# Altium schematic sheet UTHP BeagleBone Headers v2.SchDoc
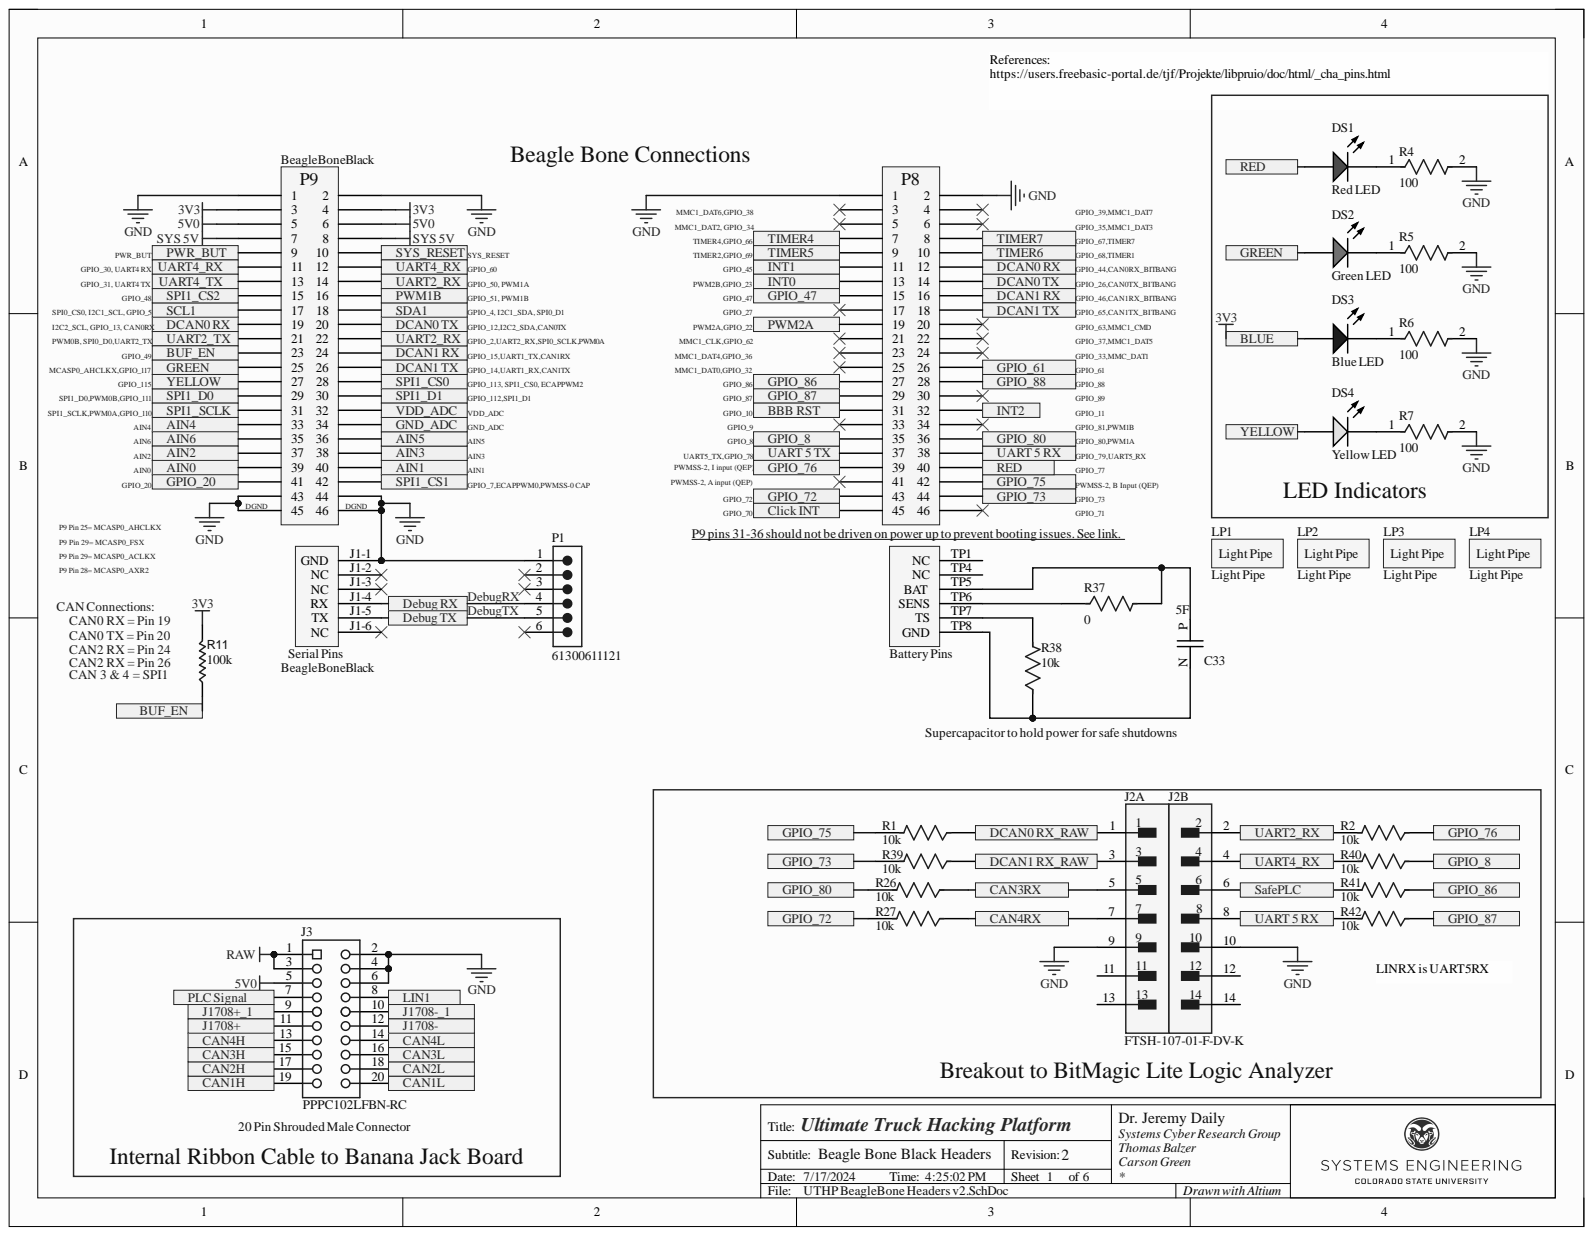
[diagram p0: UTHP BeagleBone Headers v2.SchDoc]

## Parts
BeagleBoneBlack  BeagleBoneBlack  [Beagleboneblack]
C33  5F  [New footprint 1]
DS1  Red LED  [LTST-C191KRKT_V]
DS2  Green LED  [LTST-C190GKT_V]
DS3  Blue LED  [LTST-C190TBKT-2_V]
DS4  Yellow LED  [LTST-C190KSKT_V]
J2  FTSH-107-01-F-DV-K  [FP-FTSH-107-01-F-DV-K-MFG]  (parts A,B)
J3  PPPC102LFBN-RC  Contact Gender: Male  [SLIN-PPPC102LFBN-RC_V]
LP1  Light Pipe  [Lightpipe - SLP3]
LP2  Light Pipe  [Lightpipe - SLP3]
LP3  Light Pipe  [Lightpipe - SLP3]
LP4  Light Pipe  [Lightpipe - SLP3]
P1  61300611121  [61300611121]
R1  10k  [FP-KTR03-IPC_B]
R2  10k  [FP-KTR03-IPC_B]
R4  100  [FP-CRGCQ0603-IPC_C]
R5  100  [FP-CRGCQ0603-IPC_C]
R6  100  [FP-CRGCQ0603-IPC_C]
R7  100  [FP-CRGCQ0603-IPC_C]
R11  value 100k  [RESC1608X55X25ML10T15]  (hidden comment: Resistor 100k +/-1% 0603 100 mW)
R26  10k  [FP-KTR03-IPC_B]
R27  10k  [FP-KTR03-IPC_B]
R37  0  [FP-RMCF0805-MFG]
R38  10k  [FP-KTR03-IPC_B]
R39  10k  [FP-KTR03-IPC_B]
R40  10k  [FP-KTR03-IPC_B]
R41  10k  [FP-KTR03-IPC_B]
R42  10k  [FP-KTR03-IPC_B]

## Ports
AIN0, AIN1, AIN2, AIN3, AIN4, AIN5, AIN6, BBB RST, BLUE, BUF_EN, CAN1H, CAN1L, CAN2H, CAN2L, CAN3H, CAN3L, CAN3RX, CAN4H, CAN4L, CAN4RX, Click INT, DCAN0 RX, DCAN0 RX_RAW, DCAN0 TX, DCAN1 RX, DCAN1 RX_RAW, DCAN1 TX, Debug RX, Debug TX, GND_ADC, GPIO_8, GPIO_20, GPIO_47, GPIO_61, GPIO_72, GPIO_73, GPIO_75, GPIO_76, GPIO_80, GPIO_86, GPIO_87, GPIO_88, GREEN, INT0, INT1, INT2, J1708+, J1708+_1, J1708-, J1708-_1, LIN1, PLC Signal, PWM1B, PWM2A, PWR_BUT, RED, SafePLC, SCL1, SDA1, SPI1_CS0, SPI1_CS1, SPI1_CS2, SPI1_D0, SPI1_D1, SPI1_SCLK, SYS_RESET, TIMER4, TIMER5, TIMER6, TIMER7, UART2_RX, UART2_TX, UART4_RX, UART4_TX, UART 5 RX, UART 5 TX, VDD_ADC, YELLOW

## Nets
5V0: BeagleBoneBlack.5 BeagleBoneBlack.6 J3.5
GND: BeagleBoneBlack.1 BeagleBoneBlack.2 BeagleBoneBlack.43 BeagleBoneBlack.44 BeagleBoneBlack.45 BeagleBoneBlack.46 BeagleBoneBlack.J1-1 BeagleBoneBlack.P1 BeagleBoneBlack.P2 J2.9 J2.10 J3.2 J3.4 J3.6 P1.1 R4.2 R5.2 R6.2 R7.2
RAW: J3.1 J3.3
SYS 5V: BeagleBoneBlack.7 BeagleBoneBlack.8
3V3: BeagleBoneBlack.3 BeagleBoneBlack.4 DS3.1 R11.2 ; port BLUE
AIN0: BeagleBoneBlack.39 ; port AIN0
AIN1: BeagleBoneBlack.40(AIN1) ; port AIN1
AIN2: BeagleBoneBlack.37 ; port AIN2
AIN3: BeagleBoneBlack.38(AIN3) ; port AIN3
AIN4: BeagleBoneBlack.33 ; port AIN4
AIN5: BeagleBoneBlack.36(AIN5) ; port AIN5
AIN6: BeagleBoneBlack.35 ; port AIN6
BBB RST: BeagleBoneBlack.P31(BBB_RST) ; port BBB RST
BUF_EN: BeagleBoneBlack.23 R11.1 ; port BUF_EN
CAN1H: J3.19 ; port CAN1H
CAN1L: J3.20 ; port CAN1L
CAN2H: J3.17 ; port CAN2H
CAN2L: J3.18 ; port CAN2L
CAN3H: J3.15 ; port CAN3H
CAN3L: J3.16 ; port CAN3L
CAN3RX: J2.5 R26.1 ; port CAN3RX
CAN4H: J3.13 ; port CAN4H
CAN4L: J3.14 ; port CAN4L
CAN4RX: J2.7 R27.1 ; port CAN4RX
Click INT: BeagleBoneBlack.P45(Click_INT) ; port Click INT
DCAN0 RX: BeagleBoneBlack.19 BeagleBoneBlack.P12 ; port DCAN0 RX
DCAN0 RX_RAW: J2.1 R1.1 ; port DCAN0 RX_RAW
DCAN0 TX: BeagleBoneBlack.20(DCAN0_TX) BeagleBoneBlack.P14 ; port DCAN0 TX
DCAN1 RX: BeagleBoneBlack.24(DCAN1_RX) BeagleBoneBlack.P16 ; port DCAN1 RX
DCAN1 RX_RAW: J2.3 R39.1 ; port DCAN1 RX_RAW
DCAN1 TX: BeagleBoneBlack.26(DCAN1_TX) BeagleBoneBlack.P18 ; port DCAN1 TX
DebugRX: BeagleBoneBlack.J1-4(Debug_RX) P1.4 ; port Debug RX
DebugTX: BeagleBoneBlack.J1-5(Debug_TX) P1.5 ; port Debug TX
GND_ADC: BeagleBoneBlack.34(GND_ADC) ; port GND_ADC
GPIO_8: BeagleBoneBlack.P35 R40.1 ; port GPIO_8
GPIO_20: BeagleBoneBlack.41 ; port GPIO_20
GPIO_47: BeagleBoneBlack.P15(GPIO_47) ; port GPIO_47
GPIO_61: BeagleBoneBlack.P26 ; port GPIO_61
GPIO_72: BeagleBoneBlack.P43(GPIO_72) R27.2 ; port GPIO_72
GPIO_73: BeagleBoneBlack.P44 R39.2 ; port GPIO_73
GPIO_75: BeagleBoneBlack.P42 R1.2 ; port GPIO_75
GPIO_76: BeagleBoneBlack.P39(GPIO_76) R2.1 ; port GPIO_76
GPIO_80: BeagleBoneBlack.P36 R26.2 ; port GPIO_80
GPIO_86: BeagleBoneBlack.P27(GPIO_86) R41.1 ; port GPIO_86
GPIO_87: BeagleBoneBlack.P29(GPIO_87) R42.1 ; port GPIO_87
GPIO_88: BeagleBoneBlack.P28 ; port GPIO_88
GREEN: BeagleBoneBlack.25 DS2.1 ; port GREEN
INT0: BeagleBoneBlack.P13 ; port INT0
INT1: BeagleBoneBlack.P11 ; port INT1
INT2: BeagleBoneBlack.P32 ; port INT2
J1708+: J3.11 ; port J1708+
J1708+_1: J3.9 ; port J1708+_1
J1708-: J3.12 ; port J1708-
J1708-_1: J3.10 ; port J1708-_1
LIN1: J3.8 ; port LIN1
PLC Signal: J3.7 ; port PLC Signal
PWM1B: BeagleBoneBlack.16(PWM1B) ; port PWM1B
PWM2A: BeagleBoneBlack.P19(PWM2A) ; port PWM2A
PWR_BUT: BeagleBoneBlack.9 ; port PWR_BUT
RED: BeagleBoneBlack.P40 DS1.1 ; port RED
SafePLC: J2.6 R41.2 ; port SafePLC
SCL1: BeagleBoneBlack.17 ; port SCL1
SDA1: BeagleBoneBlack.18(SDA1) ; port SDA1
SPI1_CS0: BeagleBoneBlack.28(SPI1_CS0) ; port SPI1_CS0
SPI1_CS1: BeagleBoneBlack.42(SPI1_CS1) ; port SPI1_CS1
SPI1_CS2: BeagleBoneBlack.15 ; port SPI1_CS2
SPI1_D0: BeagleBoneBlack.29 ; port SPI1_D0
SPI1_D1: BeagleBoneBlack.30(SPI1_D1) ; port SPI1_D1
SPI1_SCLK: BeagleBoneBlack.31 ; port SPI1_SCLK
SYS_RESET: BeagleBoneBlack.10(SYS_RESET) ; port SYS_RESET
TIMER4: BeagleBoneBlack.P7(TIMER4) ; port TIMER4
TIMER5: BeagleBoneBlack.P9(TIMER5) ; port TIMER5
TIMER6: BeagleBoneBlack.P10 ; port TIMER6
TIMER7: BeagleBoneBlack.P8 ; port TIMER7
UART2_RX: BeagleBoneBlack.14(UART2_RX) BeagleBoneBlack.22(UART2_RX) J2.2 R2.2 ; port UART2_RX
UART2_TX: BeagleBoneBlack.21 ; port UART2_TX
UART4_RX: BeagleBoneBlack.11 BeagleBoneBlack.12(UART4_RX) J2.4 R40.2 ; port UART4_RX
UART4_TX: BeagleBoneBlack.13 ; port UART4_TX
UART 5 RX: BeagleBoneBlack.P38 J2.8 R42.2 ; port UART 5 RX
UART 5 TX: BeagleBoneBlack.P37(UART_5_TX) ; port UART 5 TX
VDD_ADC: BeagleBoneBlack.32(VDD_ADC) ; port VDD_ADC
YELLOW: BeagleBoneBlack.27 DS4.1 ; port YELLOW
NetBeagleBoneBlack_TP5: BeagleBoneBlack.TP5(BAT) C33.P R37.2
NetBeagleBoneBlack_TP6: BeagleBoneBlack.TP6(SENS) R37.1
NetBeagleBoneBlack_TP7: BeagleBoneBlack.TP7(TS) R38.2
NetBeagleBoneBlack_TP8: BeagleBoneBlack.TP8(GND) C33.N R38.1
NetDS1_2: DS1.2 R4.1
NetDS2_2: DS2.2 R5.1
NetDS3_2: DS3.2 R6.1
NetDS4_2: DS4.2 R7.1

Not connected: BeagleBoneBlack J1-2 J1-3 J1-6 P3 P4 P5 P6 P17 P20 P21 P22 P23 P24 P25 P30 P33 P34 P41 P46 TP1(NC) TP4(NC); J2 11 12 13 14; P1 2 3 6

## Library data of the parts
BeagleBoneBlack = CMP-061-000000-1: Revision C with all headers and test points; Manufacturer: BeagleBoard by Seeed Studio; Part Number: 102110420; Value: BeagleBone Black Revision C; Component Kind: Standard; Supplier 1: Digi-Key; Supplier Part Number 1: 2820-102110420-ND; Library Name: CSU Systems Cyber
C33 = CMP-001-00088-1: DSF505Q6R0JBG; Manufacturer: Panasonic Electronic Components; Part Number: ERJ-3EKF4701V; Value: 4.7k; Component Kind: Standard; Supplier 1: Digi-Key; Supplier Part Number 1: P4.70KHCT-ND; Library Name: CSU Systems Cyber
DS1 = CMP-007-00024-2: LED Uni-Color Red, 62.5 mW, 25 mA, -30 to 85 degC, 2-Pin SMD, RoHS, Tape and Reel; Manufacturer: Lite-On; Component Kind: Standard; Library Name: CSU Systems Cyber
DS2 = CMP-007-00022-2: LED Uni-Color Green, 100 mW, 30 mA, -55 to 85 degC, 2-Pin SMD, RoHS, Tape and Reel; Manufacturer: Lite-On; Component Kind: Standard; Library Name: CSU Systems Cyber
DS3 = CMP-007-00026-2: LED Uni-Color Blue, 76 mW, 20 mA, -20 to 80 degC, 2-Pin SMD, RoHS, Tape and Reel; Manufacturer: Lite-On; Component Kind: Standard; Library Name: CSU Systems Cyber
DS4 = CMP-007-00025-2: LED Uni-Color Yellow, 75 mW, 30 mA, -30 to 85 degC, 2-Pin SMD, RoHS, Tape and Reel; Manufacturer: Lite-On; Component Kind: Standard; Library Name: CSU Systems Cyber
J2 = CMP-02766-001448-1: CONN HEADER SMD 14POS 1.27MM; Connector Type: Male Pin; Gender: Male; Number of Contacts: 14; Row Spacing: 0.05inch; Color: Black; Component Kind: Standard; Contact Material: Bronze; Contact Mating Length: 0.12inch; Contact Plating: Gold; Contact Type: Male Pin; Depth: 5.84mm; Housing Color: Black; Housing Material: Polymer; Lead Free: Lead Free; Length: 8.89mm; Library Name: Altium Content Vault; Max Operating Temperature: 125°C; Min Operating Temperature: -55°C; Mount: Surface Mount; Number of Positions: 14; Number of Rows: 2; Orientation: Straight; Package Quantity: 1; Packaging: Rail/Tube; Pitch: 1.27mm; Radiation Hardening: No; RoHS Compliant: Yes; Series: FTSH; Termination: Solder
J3 = CMP-002-00029-2: Male Header, Pitch 2.54 mm, 2 x 10 Position, Height 8.75 mm, Tail Length 3.2 mm, -40 to 105 degC, RoHS, Bulk; Manufacturer: Molex; Manufacturer Part Number: 87834-2019; Additional Feature: POLARIZED; Body Breadth: 0.35inch; Body Depth: 0.365inch; Body Length: 1.3inch; Body/Shell Style: RECEPTACLE; Connector Type: BOARD CONNECTOR; Contact Pattern: RECTANGULAR; Contact Resistance: 15mR; Contact Style: SQ PIN-SKT; Dielectric Withstanding Voltage (AC): 900V; DIN Conformance: No; Durability: 50Cycles; Insulation Resistance: 12GR; Mating Contact Pitch: 0.1inch; Mounting Option 1: GUIDE SLOT; Mounting Option 2: LOCKING; Number Of Connectors: 1; Number of Contacts: 20; Number Of PCB Rows: 2; Option: General Purpose; PCB Contact Row Spacing: 2.54mm; Plating Thickness: 5uin; Polarization Key: POLARIZED HOUSING; Rated Current (Signal): 2.5A; Reference Standard: UL; Reliability: COMMERCIAL; Category: Headers and Wire Housings; Component Kind: Standard; ComponentLink1Description: https://datasheet.ciiva.com:443/pdfs/VipMasterIC/IC/MOLE/MOLES56703/MOLES56703-1.pdf?src-supplier=IHS+Markit; ComponentLink1URL: https://datasheet.ciiva.com:443/pdfs/VipMasterIC/IC/MOLE/MOLES56703/MOLES56703-1.pdf?src-supplier=IHS+Markit; ComponentLink2Description: https://datasheet.ciiva.com/12918/0878342019-pcb-headers-152849-12918437.pdf?src-supplier=Mouser; ComponentLink2URL: https://datasheet.ciiva.com/12918/0878342019-pcb-headers-152849-12918437.pdf?src-supplier=Mouser; ComponentLink3Description: https://datasheet.ciiva.com/10076/0878342019-pcb-headers-10076920.pdf?src-supplier=Avnet; ComponentLink3URL: https://datasheet.ciiva.com/10076/0878342019-pcb-headers-10076920.pdf?src-supplier=Avnet; Contact Finish - Mating: NOT SPECIFIED; Filter Feature: No; Flammability Rating: UL94 V-0; IEC Conformance: No; Insulation Color: Black; Insulation Material: Polyester; Mating Contact Row Spacing: 0.1inch; Max Operating Temperature: 120°C; MIL Conformance: No; Min Operating Temperature: -55°C; Mixed Contacts: No; Mounting Style: STRAIGHT; Number of Rows Loaded: 2; PCB Contact Pattern: RECTANGULAR; Reach SVHC Compliant: Yes; RoHS: Yes; RoHS Compliant: Yes; Supplier: Digi-key; Supplier Part Number: WM20559-ND; Terminal Length: 0.135inch; Terminal Pitch: 2.54mm; Termination: Solder; Library Name: CSU Systems Cyber
LP1-LP4 = CMP-023-000058-1: Component Kind: Standard; Component Type: Standard; Design Item ID: Light Pipe; Pin Count: 0; Source: SSS Schematic Library.SchLib; Library Name: CSU Systems Cyber
P1 = CMP-1502-01070-2: Component Kind: Standard; Library Name: Altium Content Vault; Termination: Through Hole
R1 R2 R26 R27 R38-R42 = CMP-08839-030382-1: 10 kOhms ±1% 0.1W, 1/10W Chip Resistor 0603 (1608 Metric) Automotive AEC-Q200, High Voltage Thick Film; Manufacturer: Rohm Semiconductor; Part Number: KTR03EZPF1002; Component Kind: Standard; Library Name: Altium Content Vault; Supplier 1: Digi-Key; Supplier Part Number 1: RHM10.0KAYCT-ND; Value: 10k
R4-R7 = CMP-03211-002191-1: CRGCQ0603 100Ω 1% ±200ppm/°C 75V; Manufacturer: TE Connectivity; Manufacturer Part Number: CRGCQ0603F100R; Component Kind: Standard; Library Name: Altium Content Vault; Value: 100Ω
R11 = CMP-009-00023-7: Chip Resistor, 100 KOhm, +/- 1%, 0.1 W, -55 to 155 degC, 0603 (1608 Metric); Power: 100mW; Voltage Rating: 50V; Case/Package: 0603; Component Kind: Standard; Max Operating Temperature: 155°C; Min Operating Temperature: -55°C; Mounting Technology: SM; Pins: 2; RoHS Compliant: True; Tolerance: 1%; Library Name: CSU Systems Cyber
R37 = CMP-26527-000017-1: RES 0 OHM JUMPER 1/8W 0805; Manufacturer: Stackpole Electronics Inc; Part Number: RMCF0805ZT0R00; Component Kind: Standard; Library Name: Altium Content Vault; Supplier 1: Digi-Key; Supplier Part Number 1: RMCF0805ZT0R00CT-ND; Value: 0
